# Altium schematic sheet UI_MAIN.SchDoc
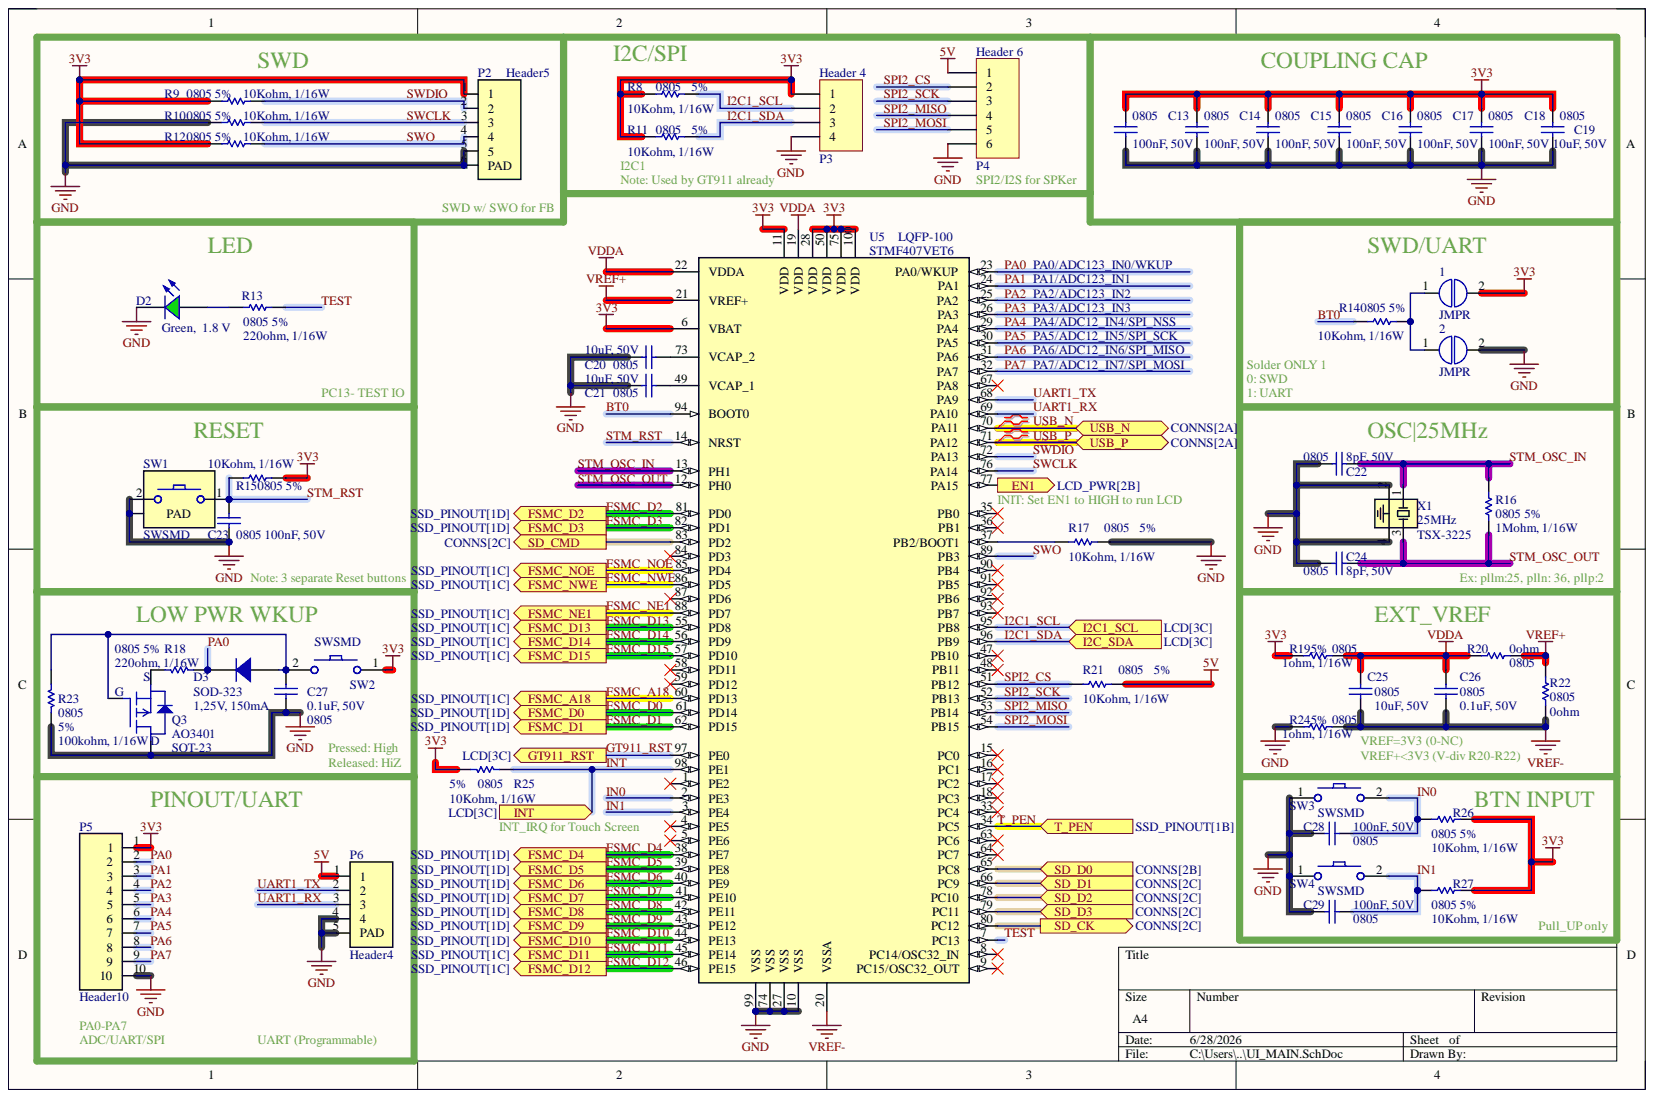
[diagram p0: UI_MAIN.SchDoc]

## Parts
1  JMPR  [JMPR]  (jumper)
2  JMPR  [JMPR]  (jumper)
C13  100nF, 50V  Package: 0805  [C_0805]
C14  100nF, 50V  Package: 0805  [C_0805]
C15  100nF, 50V  Package: 0805  [C_0805]
C16  100nF, 50V  Package: 0805  [C_0805]
C17  100nF, 50V  Package: 0805  [C_0805]
C18  100nF, 50V  Package: 0805  [C_0805]
C19  10uF, 50V  Package: 0805  [C_0805]
C20  10uF, 50V  Package: 0805  [C_0805]
C21  10uF, 50V  Package: 0805  [C_0805]
C22  8pF, 50V  Package: 0805  [C_0805]
C23  100nF, 50V  Package: 0805  [C_0805]
C24  8pF, 50V  Package: 0805  [C_0805]
C25  10uF, 50V  Package: 0805  [C_0805]
C26  0.1uF, 50V  Package: 0805  [C_0805]
C27  0.1uF, 50V  Package: 0805  [C_0805]
C28  100nF, 50V  Package: 0805  [C_0805]
C29  100nF, 50V  Package: 0805  [C_0805]
D2  Green,  1.8 V  [LED_0603]
D3  1,25V, 150mA  Package: SOD-323  [PKG_SOD-323]
P2  Header5  [CONN_Header5]
P3  Header 4  [JP4]
P4  Header 6  [JP6]
P5  Header10  [CONN_Header10]
P6  Header4  [CONN_Header4]
Q3  AO3401  Package: SOT-23  [PKG_SOT-23]
R8  10Kohm, 1/16W  Acc: 5%  Package: 0805  [R_0805]
R9  10Kohm, 1/16W  Acc: 5%  Package: 0805  [R_0805]
R10  10Kohm, 1/16W  Acc: 5%  Package: 0805  [R_0805]
R11  10Kohm, 1/16W  Acc: 5%  Package: 0805  [R_0805]
R12  10Kohm, 1/16W  Acc: 5%  Package: 0805  [R_0805]
R13  220ohm, 1/16W  Acc: 5%  Package: 0805  [R_0805]
R14  10Kohm, 1/16W  Acc: 5%  Package: 0805  [R_0805]
R15  10Kohm, 1/16W  Acc: 5%  Package: 0805  [R_0805]
R16  1Mohm, 1/16W  Package: 0805  [R_0805]
R17  10Kohm, 1/16W  Package: 0805  [R_0805]
R18  220ohm, 1/16W  Acc: 5%  Package: 0805  [R_0805]
R19  1ohm, 1/16W  Acc: 5%  Package: 0805  [R_0805]
R20  0ohm  Package: 0805  [R_0805]
R21  10Kohm, 1/16W  Package: 0805  [R_0805]
R22  0ohm  Package: 0805  [R_0805]
R23  100kohm, 1/16W  Acc: 5%  Package: 0805  [R_0805]
R24  1ohm, 1/16W  Acc: 5%  Package: 0805  [R_0805]
R25  10Kohm, 1/16W  Acc: 5%  Package: 0805  [R_0805]
R26  10Kohm, 1/16W  Acc: 5%  Package: 0805  [R_0805]
R27  10Kohm, 1/16W  Acc: 5%  Package: 0805  [R_0805]
SW1  SWSMD  [SW_SMD]
SW2  SWSMD  [SW_SMD_NOGND]
SW3  SWSMD  [SW_SMD_NOGND]
SW4  SWSMD  [SW_SMD_NOGND]
U5  STMF407VET6  Package: LQFP-100  [PKG_LQFP-100]
X1  25MHz  Package: TSX-3225  [PKG_TSX-3225]

## Ports
EN1, FSMC_A18, FSMC_D0, FSMC_D1, FSMC_D2, FSMC_D3, FSMC_D4, FSMC_D5, FSMC_D6, FSMC_D7, FSMC_D8, FSMC_D9, FSMC_D10, FSMC_D11, FSMC_D12, FSMC_D13, FSMC_D14, FSMC_D15, FSMC_NE1, FSMC_NOE, FSMC_NWE, GT911_RST, I2C1_SCL, I2C_SDA, INT, SD_CK, SD_CMD, SD_D0, SD_D1, SD_D2, SD_D3, T_PEN, USB_N, USB_P

## Nets
3V3: 1.2 C13.2 C14.2 C15.2 C16.2 C17.2 C18.2 C19.2 P2.1 P3.1 P5.1 R8.2 R9.2 R11.2 R12.2 R15.1 R19.2 R25.1 R26.1 R27.1 SW2.1 U5.6(VBAT) U5.11(VDD) U5.28(VDD) U5.50(VDD) U5.75(VDD) U5.100(VDD)
5V: P4.1 P6.1 R21.2
GND: 2.2 C13.1 C14.1 C15.1 C16.1 C17.1 C18.1 C19.1 C20.2 C21.2 C22.1 C23.1 C24.1 C27.1 C28.2 C29.2 D2.1 P2.5 P2.6(PAD) P3.4 P4.6 P5.10 P6.4 P6.5(PAD) Q3.3 R10.2 R17.2 R23.2 R24.2 SW1.0 SW1.2 SW3.1 SW4.1 U5.10(VSS) U5.27(VSS) U5.74(VSS) U5.99(VSS) X1.2 X1.4
VDDA: C25.2 C26.2 R19.1 R20.1 U5.19(VDD) U5.22(VDDA)
VREF+: R20.2 R22.2 U5.21(VREF+)
VREF-: C25.1 C26.1 R22.1 R24.1 U5.20(VSSA)
BT0: R14.1 U5.94(BOOT0)
EN1: U5.77(PA15) ; port EN1
FSMC_A18: U5.60(PD13) ; port FSMC_A18
FSMC_D0: U5.61(PD14) ; port FSMC_D0
FSMC_D1: U5.62(PD15) ; port FSMC_D1
FSMC_D2: U5.81(PD0) ; port FSMC_D2
FSMC_D3: U5.82(PD1) ; port FSMC_D3
FSMC_D4: U5.38(PE7) ; port FSMC_D4
FSMC_D5: U5.39(PE8) ; port FSMC_D5
FSMC_D6: U5.40(PE9) ; port FSMC_D6
FSMC_D7: U5.41(PE10) ; port FSMC_D7
FSMC_D8: U5.42(PE11) ; port FSMC_D8
FSMC_D9: U5.43(PE12) ; port FSMC_D9
FSMC_D10: U5.44(PE13) ; port FSMC_D10
FSMC_D11: U5.45(PE14) ; port FSMC_D11
FSMC_D12: U5.46(PE15) ; port FSMC_D12
FSMC_D13: U5.55(PD8) ; port FSMC_D13
FSMC_D14: U5.56(PD9) ; port FSMC_D14
FSMC_D15: U5.57(PD10) ; port FSMC_D15
FSMC_NE1: U5.88(PD7) ; port FSMC_NE1
FSMC_NOE: U5.85(PD4) ; port FSMC_NOE
FSMC_NWE: U5.86(PD5) ; port FSMC_NWE
GT911_RST: U5.97(PE0) ; port GT911_RST
I2C1_SCL: P3.2 R8.1 U5.95(PB8) ; port I2C1_SCL
I2C1_SDA: P3.3 R11.1 U5.96(PB9) ; port I2C_SDA
IN0: C28.1 R26.2 SW3.2 U5.2(PE3)
IN1: C29.1 R27.2 SW4.2 U5.3(PE4)
INT: R25.2 U5.98(PE1) ; port INT
PA0: D3.1 P5.2 R18.2 U5.23(PA0/WKUP)
PA1: P5.3 U5.24(PA1)
PA2: P5.4 U5.25(PA2)
PA3: P5.5 U5.26(PA3)
PA4: P5.6 U5.29(PA4)
PA5: P5.7 U5.30(PA5)
PA6: P5.8 U5.31(PA6)
PA7: P5.9 U5.32(PA7)
SD_CK: U5.80(PC12) ; port SD_CK
SD_CMD: U5.83(PD2) ; port SD_CMD
SD_D0: U5.65(PC8) ; port SD_D0
SD_D1: U5.66(PC9) ; port SD_D1
SD_D2: U5.78(PC10) ; port SD_D2
SD_D3: U5.79(PC11) ; port SD_D3
SPI2_CS: P4.2 R21.1 U5.51(PB12)
SPI2_MISO: P4.4 U5.53(PB14)
SPI2_MOSI: P4.5 U5.54(PB15)
SPI2_SCK: P4.3 U5.52(PB13)
STM_OSC_IN: C22.2 R16.1 U5.13(PH1) X1.1
STM_OSC_OUT: C24.2 R16.2 U5.12(PH0) X1.3
STM_RST: C23.2 R15.2 SW1.1 U5.14(NRST)
SWCLK: P2.3 R10.1 U5.76(PA14)
SWDIO: P2.2 R9.1 U5.72(PA13)
SWO: P2.4 R12.1 U5.89(PB3)
T_PEN: U5.34(PC5) ; port T_PEN
TEST: R13.2 U5.7(PC13)
UART1_RX: P6.3 U5.69(PA10)
UART1_TX: P6.2 U5.68(PA9)
USB_N: U5.70(PA11) ; port USB_N
USB_P: U5.71(PA12) ; port USB_P
Net1_1: 1.1 2.1 R14.2
NetC20_1: C20.1 U5.73(VCAP_2)
NetC21_1: C21.1 U5.49(VCAP_1)
NetC27_2: C27.2 D3.2 Q3.1 R23.1 SW2.2
NetD2_2: D2.2 R13.1
NetQ3_2: Q3.2 R18.1
NetR17_1: R17.1 U5.37(PB2/BOOT1)

Not connected: U5 1(PE2) 4(PE5) 5(PE6) 8(PC14/OSC32_IN) 9(PC15/OSC32_OUT) 15(PC0) 16(PC1) 17(PC2) 18(PC3) 33(PC4) 35(PB0) 36(PB1) 47(PB10) 48(PB11) 58(PD11) 59(PD12) 63(PC6) 64(PC7) 67(PA8) 84(PD3) 87(PD6) 90(PB4) 91(PB5) 92(PB6) 93(PB7)

## Library data of the parts
C13-C29 = CAP_0805: Manufacturer 1: Vishay; Manufacturer Part Number 1: CAP0805; Component Kind: Standard; Datasheet: https://www.mouser.de/datasheet/2/447/PYu_RC_Group_51_RoHS_L_11-1984063.pdf; Description: CAP_0805; Library Name: DisplayLib.IntLib; Supplier 1: Thegioiic; Supplier Link: N/a
  C13-C18 C23 C28 C29: Value: 100nF, 50V
  C19-C21 C25: Value: 10uF, 50V
  C22 C24: Value: 8pF, 50V
  C26 C27: Value: 0.1uF, 50V
D2 = GREEN: Green LED; Manufacturer 1: LITE-ON; Manufacturer Part Number 1: LEDG; Component Kind: Standard; ComponentLink1Description: Datasheet link; ComponentLink1URL: N/A; ComponentLink2Description: Supplier 1 URL; ComponentLink2URL: https://www.mouser.vn/ProductDetail/Broadcom-Avago/HSMG-C170?qs=YDL0qNrpDT4lm8ArMPkMEA%3D%3D; ComponentLink3Description: Supplier 2 URL; ComponentLink3URL: SML-LXT0805GW-TR Lumex Opto/Components Inc. | Optoelectronics | DigiKey; ComponentLink4Description: Supplier 3 URL; ComponentLink4URL: N/A; Datasheet: https://www.mouser.com/datasheet/2/239/LTST-S326KGKFKT-1143909.pdf?srsltid=AfmBOorgg6Gh4PD3T9FRO6Da906Aqdqx3RSjbUQRSIXh-3hATfgAoF8Q; Library Name: DisplayLib.IntLib; Package: 1206; Supplier 1: ICDAYROI; Supplier Link: https://icdayroi.com/led-smd-0805; Value: Green,  1.8 V
D3 = DIODE: 1N4148W; Manufacturer 1: OEM; Manufacturer Part Number 1: N/a; Component Kind: Standard; ComponentLink1Description: Datasheet; ComponentLink1URL: https://www.mouser.vn/datasheet/2/345/fm4001_fm4007-1113844.pdf; Datasheet: N/a; Library Name: DisplayLib.IntLib; Supplier 1: Thegioiic; Supplier Link: N/a; Value: 1,25V, 150mA
P2 = HEADER5: Header 5 pins (2.54mm); Manufacturer 1: OEM; Manufacturer Part Number 1: XH2.54mm 5; Component Kind: Standard; Datasheet: N/a; Library Name: DisplayLib.IntLib; Supplier 1: Thegioiic; Supplier Link: https://www.thegioiic.com/dau-xh2-54mm-5-chan-dan-smd-nam-ngang
P3 = Header 4: Header, 4-Pin; Component Kind: Standard; LatestRevisionDate: 17-Jul-2002; LatestRevisionNote: Re-released for DXP Platform.; Library Name: PIF_Library.IntLib; Publisher: Altium Limited
P4 = Header 6: Header, 6-Pin; Component Kind: Standard; LatestRevisionDate: 17-Jul-2002; LatestRevisionNote: Re-released for DXP Platform.; Library Name: PIF_Library.IntLib; Publisher: Altium Limited
P5 = HEADER10: NOTE: FOOTPRINT IS 2.54mm MEANWHILE 3D IS 2.5mm.; Manufacturer 1: OEM; Manufacturer Part Number 1: XH2.54mm 10; Component Kind: Standard; Datasheet: N/a; Library Name: DisplayLib.IntLib; Supplier 1: Thegioiic; Supplier Link: https://www.thegioiic.com/dau-xh2-54mm-10-chan-thang-xuyen-lo
P6 = HEADER4: Header 4 pins (2.54mm); Manufacturer 1: OEM; Manufacturer Part Number 1: XH2.54mm 4; Component Kind: Standard; Datasheet 1: N/a; Library Name: DisplayLib.IntLib; Supplier 1: Thegioiic; Supplier Link: https://www.thegioiic.com/dau-xh2-54mm-4-chan-dan-smd-nam-ngang
Q3 = AO3401: P-Channel 30 V 4A (Ta) 1.4W (Ta) Surface Mount SOT-23-3; Manufacturer 1: Alpha&Omega Semi.; Component Kind: Standard; Datasheet: https://www.snapeda.com/parts/AO3401/Alpha%20&%20Omega%20Semiconductor/datasheet/; Library Name: DisplayLib.IntLib; Manufacturer Part Number 1: AO3401; Supplier 1: ICDayroi; Supplier Link: https://icdayroi.com/ao3401
R8-R15 R18-R20 R22-R27 = RES_0805: Manufacturer 1: Vishay; Manufacturer Part Number 1: RES0805; Component Kind: Standard; Datasheet: https://www.mouser.de/datasheet/2/447/PYu_RC_Group_51_RoHS_L_11-1984063.pdf; Description: RES_0805; Library Name: DisplayLib.IntLib; Supplier 1: Thegioiic; Supplier Link: N/a
  R8-R12 R14 R15 R25-R27: Value: 10Kohm, 1/16W
  R13 R18: Value: 220ohm, 1/16W
  R19 R24: Value: 1ohm, 1/16W
  R20 R22: Value: 0ohm
  R23: Value: 100kohm, 1/16W
R16 R17 R21 = RES_0805: Manufacturer 1: Vishay; Manufacturer Part Number 1: RES0805; Acc: 5%; Component Kind: Standard; Datasheet: https://www.mouser.de/datasheet/2/447/PYu_RC_Group_51_RoHS_L_11-1984063.pdf; Description: RES_0805; Library Name: DisplayLib.IntLib; Supplier 1: Thegioiic; Supplier Link: N/a
  R16: Value: 1Mohm, 1/16W
  R17 R21: Value: 10Kohm, 1/16W
SW1 = SWSMD: SW_SMD; Manufacturer 1: OEM; Manufacturer Part Number 1: N/a; Component Kind: Standard; Datasheet: N/a; Library Name: DisplayLib.IntLib; Supplier 1: Thegioiic; Supplier Link: https://www.thegioiic.com/nut-nhan-3x6mm-cao-3-5mm-4-chan-smd-chinh-ngang
SW2-SW4 = SWSMD_NOGND: SW_SMD; Manufacturer 1: OEM; Manufacturer Part Number 1: N/a; Component Kind: Standard; Datasheet: N/a; Library Name: DisplayLib.IntLib; Supplier 1: Thegioiic; Supplier Link: https://www.thegioiic.com/nut-nhan-3x6mm-cao-3-5mm-4-chan-smd-chinh-ngang
U5 = STMF407VET6: Arm® Cortex®-M4 32b MCU+FPU, 210DMIPS, up to 1MB flash/192+4KB RAM, USB  OTG HS/FS, Ethernet, 17 TIMs, 3 ADCs, 15 comm. interfaces, and camera; Manufacturer 1: STMicroelectronics; Component Kind: Standard; Datasheet: https://www.st.com/content/ccc/resource/technical/document/datasheet/ef/92/76/6d/bb/c2/4f/f7/DM00037051.pdf/files/DM00037051.pdf/jcr:content/translations/en.DM00037051.pdf; Library Name: DisplayLib.IntLib; Manufacturer Part Number 1: STMF407VET6; Supplier 1: Thegioiic; Supplier Link: https://www.thegioiic.com/stm32f407vet6-32-bit-arm-cortex-m4-microcontroller-168mhz-512kb-flash-100-lqfp
X1 = XTAL: Crystal, 25 MHz, -30 to 85 degC, 3.2 x 2.5 x 0.9 mm SMD; Manufacturer 1: EPSON; Manufacturer Part Number 1: TSX-3225 25.0000MF20P-C0; Component Kind: Standard; Datasheet: https://www.epsondevice.com/crystal/en/; Library Name: DisplayLib.IntLib; Supplier 1: Thegioiic; Supplier Link: https://www.thegioiic.com/thach-anh-25mhz-3225-4-chan-smd
1 2 = JMPR: Description: JMPR; Library Name: DisplayLib.IntLib
